# Altium schematic sheet Connector&Power.SchDoc(Connector&Power)
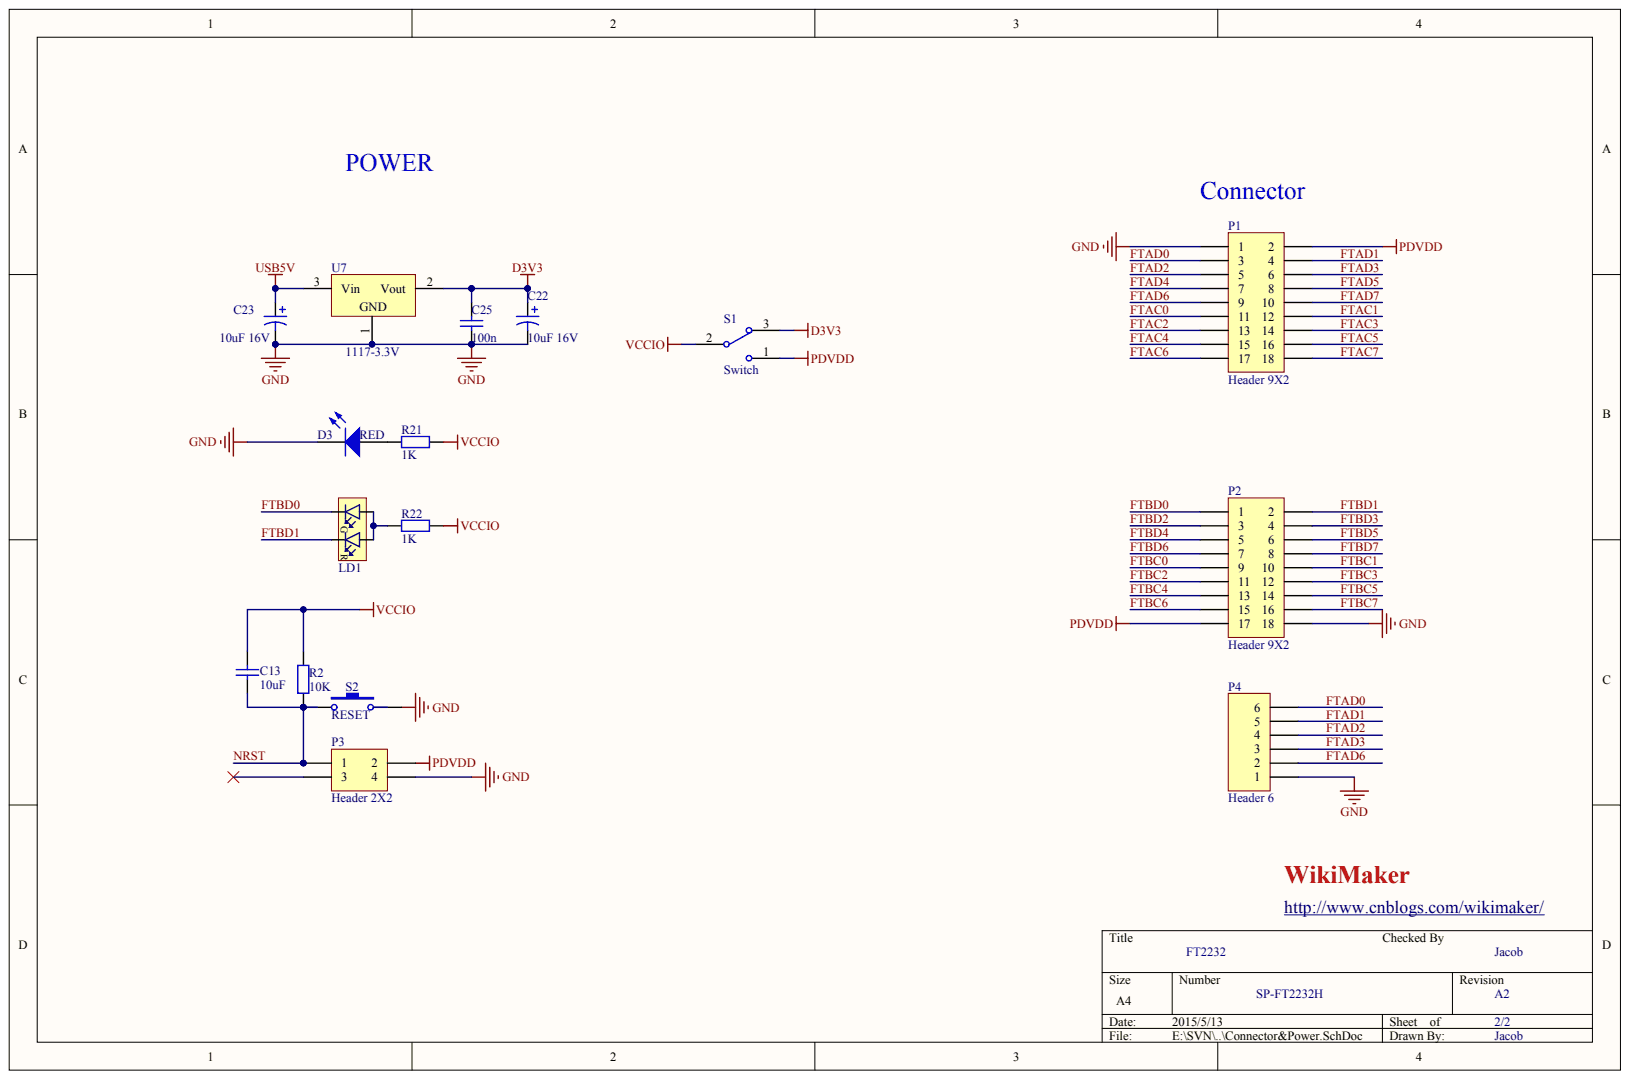
[diagram p0: Connector&Power.SchDoc]

## Parts
C13  10uF
C22  10uF 16V
C23  10uF 16V
C25  100n
D3  RED
LD1  LTST-C195KGJRKT  [LED_DUAL_0606]  (value not drawn)
P1  Header 9X2
P2  Header 9X2
P3  Header 2X2
P4  Header 6
R2  10K
R21  1K
R22  1K
S1  Switch
S2  RESET
U7  1117-3.3V

## Nets
D3V3: C22.1 C25.2 S1.3 U7.2
GND: C22.2 C23.2 C25.1 D3.2 P1.1 P2.18 P3.4 P4.1 S2.1 U7.1
PDVDD: P1.2 P2.17 P3.2 S1.1
USB5V: C23.1 U7.3
VCCIO: C13.2 R2.1 R21.1 R22.1 S1.2
FTAC0: P1.11
FTAC1: P1.12
FTAC2: P1.13
FTAC3: P1.14
FTAC4: P1.15
FTAC5: P1.16
FTAC6: P1.17
FTAC7: P1.18
FTAD0: P1.3 P4.6
FTAD1: P1.4 P4.5
FTAD2: P1.5 P4.4
FTAD3: P1.6 P4.3
FTAD4: P1.7
FTAD5: P1.8
FTAD6: P1.9 P4.2
FTAD7: P1.10
FTBC0: P2.9
FTBC1: P2.10
FTBC2: P2.11
FTBC3: P2.12
FTBC4: P2.13
FTBC5: P2.14
FTBC6: P2.15
FTBC7: P2.16
FTBD0: LD1.1 P2.1
FTBD1: LD1.2 P2.2
FTBD2: P2.3
FTBD3: P2.4
FTBD4: P2.5
FTBD5: P2.6
FTBD6: P2.7
FTBD7: P2.8
NRST: C13.1 P3.1 R2.2 S2.2
NetD3_1: D3.1 R21.2
NetLD1_3: LD1.3 LD1.4 R22.2
N00038:

Not connected: P3 3

## Library data of the parts
LD1 = (no symbol name): Manufacturer: Lite-On Inc; 2nd source part number: 859-LTST-C195KGJRKT; Note: HSMF-A203- xxxxx GaP Red GaP Emerald Green; Artikkelnr Noca: 13019145; Part Number: LTST-C195KGJRKT; Manufacturer Part Number: LTST-C195KGJRKT; Datasheet: http://media.digikey.com/pdf/Data%20Sheets/Lite-On%20PDFs/LTST-C195KGJRKT.pdf; LatestRevisionDate: 2012-07-05; Pin Count: 4; Published: 2012-07-05; Value: LTST-C195KGJRKT; Supplier: Digi-Key; Supplier part number: 160-1452-1-ND; 2nd source Supplier: Mouser; Publisher: Nordic Semiconductor
C13 C22 C23 C25 D3 P1-P4 R2 R21 R22 S1 S2 U7 = (no symbol name): Publisher: Altium Limited
  C13: Published: 8-Jun-2000; LatestRevisionDate: 17-Jul-2002; LatestRevisionNote: Re-released for DXP Platform.; PackageReference: RAD-0.3; Value: 10uF
  C22 C23: Published: 8-Jun-2000; LatestRevisionDate: 17-Jul-2002; LatestRevisionNote: Re-released for DXP Platform.; PackageReference: RB7.6-15; PackageDescription: Polarized Capacitor (Radial); 2 Leads
  C25: Published: 8-Jun-2000; LatestRevisionDate: 17-Jul-2002; LatestRevisionNote: Re-released for DXP Platform.; PackageReference: RAD-0.3; PackageDescription: Radial Cap, Thru-Hole; 2 Leads; 0.3 in Pin Spacing; Value: 100n
  D3: Published: 8-Jun-2000; LatestRevisionDate: 08-Jul-2005; LatestRevisionNote: Mech Layer 1 removed.; PackageReference: LED-0
  P1-P4: LatestRevisionDate: 17-Jul-2002; LatestRevisionNote: Re-released for DXP Platform.
  R2: Published: 8-Jun-2000; LatestRevisionDate: 17-Jul-2002; LatestRevisionNote: Re-released for DXP Platform.; PackageReference: AXIAL-0.4; Value: 10K
  R21 R22: Published: 8-Jun-2000; LatestRevisionDate: 17-Jul-2002; LatestRevisionNote: Re-released for DXP Platform.; PackageReference: AXIAL-0.4; Value: 1K
  S1: Published: 24-Mar-1999; LatestRevisionDate: 17-Dec-2003; LatestRevisionNote: Schematic revised, PCB Footprint replaced.; DatasheetVersion: 05-Dec-2000; PackageReference: TL36WW15050; PackageDescription: Switch; 4 Leads; ComponentLink1Description: Manufacturer Link; ComponentLink1URL: http://www.apem.com
  S2: Published: 24-Mar-1999; LatestRevisionDate: 17-Jul-2002; LatestRevisionNote: Re-released for DXP Platform.; PackageReference: SPST-2
  U7: Published: 24-Mar-1999; LatestRevisionDate: 29-May-2009; LatestRevisionNote: IPC-7351 Footprint Added.; PackageReference: D2PAK; PackageDescription: 3-Pin Transistor Outline Package 2.54 mm Pitch, 15.1 mm Lead Span
